# Altium schematic sheet Solder_DisScan_1B.SchDoc
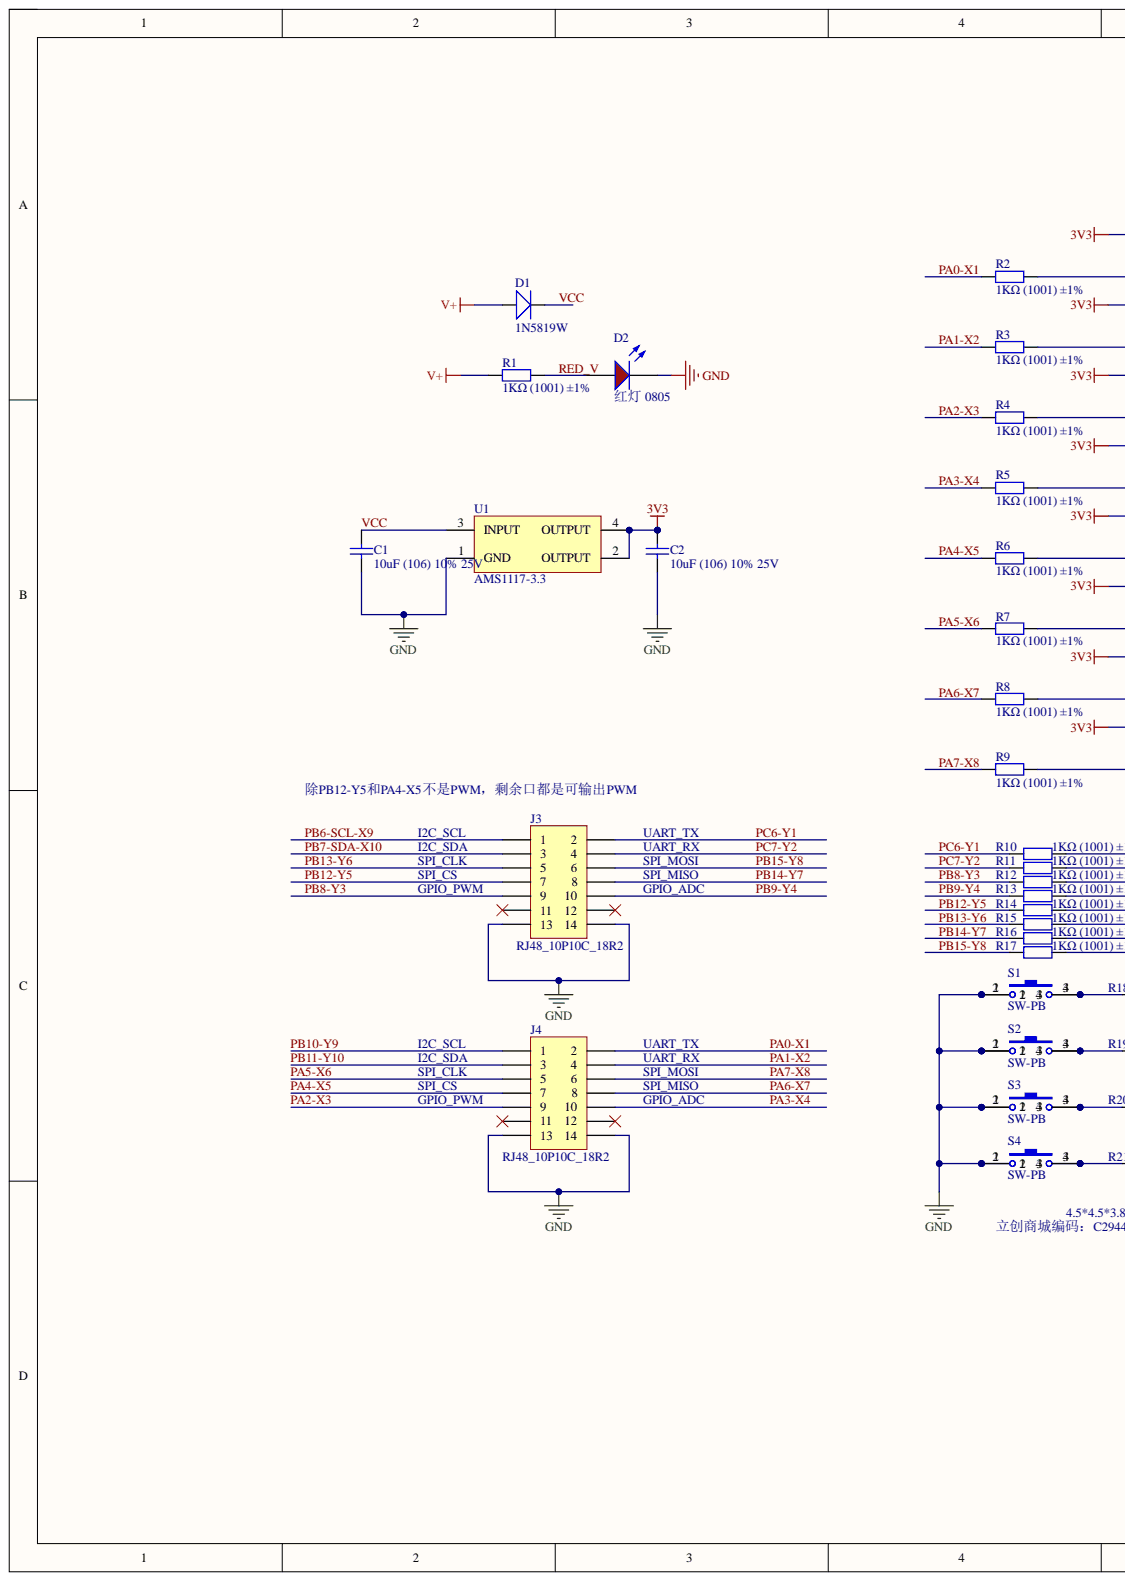
[diagram p0: Solder_DisScan_1B.SchDoc, left]
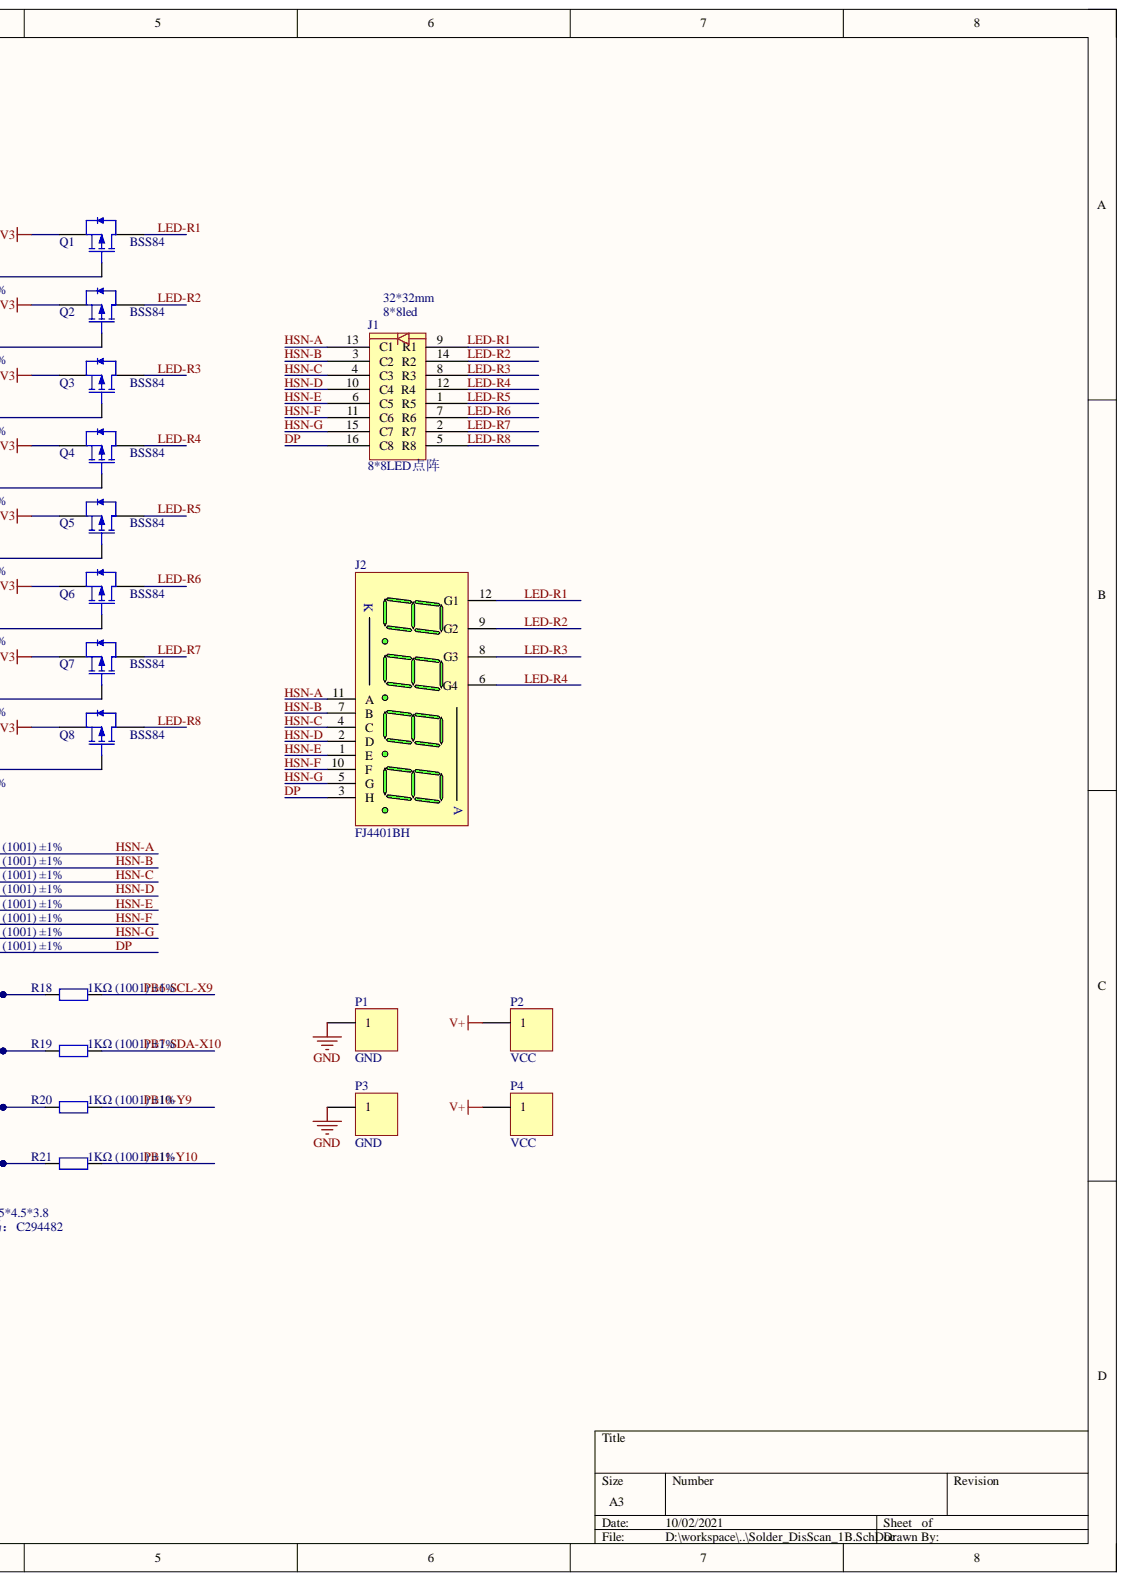
[diagram p1: Solder_DisScan_1B.SchDoc, right]

## Parts
C1  10uF (106) 10% 25V  [0805_C]
C2  10uF (106) 10% 25V  [0805_C]
D1  1N5819W  [SOD-123]
D2  红灯 0805  Package: 0805  [0805_LED_S1]
J1  8*8LED点阵  [8*8点阵LED]
J2  FJ4401BH  [FJ4401BH]
J3  RJ48_10P10C_18R2  [RJ48]
J4  RJ48_10P10C_18R2  [RJ48]
P1  GND  [HOLE]
P2  VCC  [HOLE]
P3  GND  [HOLE]
P4  VCC  [HOLE]
Q1  BSS84  [SOT-23(SOT-23-3)]
Q2  BSS84  [SOT-23(SOT-23-3)]
Q3  BSS84  [SOT-23(SOT-23-3)]
Q4  BSS84  [SOT-23(SOT-23-3)]
Q5  BSS84  [SOT-23(SOT-23-3)]
Q6  BSS84  [SOT-23(SOT-23-3)]
Q7  BSS84  [SOT-23(SOT-23-3)]
Q8  BSS84  [SOT-23(SOT-23-3)]
R1  1KΩ (1001) ±1%  [0805_R]
R2  1KΩ (1001) ±1%  [0805_R]
R3  1KΩ (1001) ±1%  [0805_R]
R4  1KΩ (1001) ±1%  [0805_R]
R5  1KΩ (1001) ±1%  [0805_R]
R6  1KΩ (1001) ±1%  [0805_R]
R7  1KΩ (1001) ±1%  [0805_R]
R8  1KΩ (1001) ±1%  [0805_R]
R9  1KΩ (1001) ±1%  [0805_R]
R10  1KΩ (1001) ±1%  [0805_R]
R11  1KΩ (1001) ±1%  [0805_R]
R12  1KΩ (1001) ±1%  [0805_R]
R13  1KΩ (1001) ±1%  [0805_R]
R14  1KΩ (1001) ±1%  [0805_R]
R15  1KΩ (1001) ±1%  [0805_R]
R16  1KΩ (1001) ±1%  [0805_R]
R17  1KΩ (1001) ±1%  [0805_R]
R18  1KΩ (1001) ±1%  [0805_R]
R19  1KΩ (1001) ±1%  [0805_R]
R20  1KΩ (1001) ±1%  [0805_R]
R21  1KΩ (1001) ±1%  [0805_R]
S1  SW-PB  [SWITCH4_4.5*4.5*4.8]
S2  SW-PB  [SWITCH4_4.5*4.5*4.8]
S3  SW-PB  [SWITCH4_4.5*4.5*4.8]
S4  SW-PB  [SWITCH4_4.5*4.5*4.8]
U1  AMS1117-3.3  [SOT223_N]

## Nets
3V3: C2.1 Q1.2 Q2.2 Q3.2 Q4.2 Q5.2 Q6.2 Q7.2 Q8.2 U1.2(OUTPUT) U1.4(OUTPUT)
GND: C1.2 C2.2 D2.K J3.13 J3.14 J4.13 J4.14 P1.1 P3.1 S1.1 S1.2 S2.1 S2.2 S3.1 S3.2 S4.1 S4.2 U1.1(GND)
V+: D1.1 P2.1 P4.1 R1.1
DP: J1.16(C8) J2.3(H) R17.2
HSN-A: J1.13(C1) J2.11(A) R10.2
HSN-B: J1.3(C2) J2.7(B) R11.2
HSN-C: J1.4(C3) J2.4(C) R12.2
HSN-D: J1.10(C4) J2.2(D) R13.2
HSN-E: J1.6(C5) J2.1(E) R14.2
HSN-F: J1.11(C6) J2.10(F) R15.2
HSN-G: J1.15(C7) J2.5(G) R16.2
LED-R1: J1.9(R1) J2.12(G1) Q1.3
LED-R2: J1.14(R2) J2.9(G2) Q2.3
LED-R3: J1.8(R3) J2.8(G3) Q3.3
LED-R4: J1.12(R4) J2.6(G4) Q4.3
LED-R5: J1.1(R5) Q5.3
LED-R6: J1.7(R6) Q6.3
LED-R7: J1.2(R7) Q7.3
LED-R8: J1.5(R8) Q8.3
PA0-X1: J4.2 R2.1
PA1-X2: J4.4 R3.1
PA2-X3: J4.9 R4.1
PA3-X4: J4.10 R5.1
PA4-X5: J4.7 R6.1
PA5-X6: J4.5 R7.1
PA6-X7: J4.8 R8.1
PA7-X8: J4.6 R9.1
PB6-SCL-X9: J3.1 R18.2
PB7-SDA-X10: J3.3 R19.2
PB8-Y3: J3.9 R12.1
PB9-Y4: J3.10 R13.1
PB10-Y9: J4.1 R20.2
PB11-Y10: J4.3 R21.2
PB12-Y5: J3.7 R14.1
PB13-Y6: J3.5 R15.1
PB14-Y7: J3.8 R16.1
PB15-Y8: J3.6 R17.1
PC6-Y1: J3.2 R10.1
PC7-Y2: J3.4 R11.1
RED_V: D2.A R1.2
VCC: C1.1 D1.2 U1.3(INPUT)
NetQ1_1: Q1.1 R2.2
NetQ2_1: Q2.1 R3.2
NetQ3_1: Q3.1 R4.2
NetQ4_1: Q4.1 R5.2
NetQ5_1: Q5.1 R6.2
NetQ6_1: Q6.1 R7.2
NetQ7_1: Q7.1 R8.2
NetQ8_1: Q8.1 R9.2
NetR18_1: R18.1 S1.3 S1.4
NetR19_1: R19.1 S2.3 S2.4
NetR20_1: R20.1 S3.3 S3.4
NetR21_1: R21.1 S4.3 S4.4

Not connected: J3 11 12; J4 11 12

## Library data of the parts
C1 C2 = 0805 10uF (106) 10% 25V: 贴片电容; Component Kind: Standard; ComponentLink1Description: 供应商链接; ComponentLink1URL: http://www.szlcsc.com/product/details_16532.html; Library Name: Cap.SchLib; Package: 0805; Supplier: LC; SuppliersPartNumber: C15850
D1 = 1N5819W: Resistor; Component Kind: Standard; LatestRevisionDate: 29-May-2009; LatestRevisionNote: IPC-7351 Footprint Added.; PackageDescription: Chip Resistor; Published: 8-Jun-2000; Signal Integrity: Res; Simulation: RESISTOR; Value: 1N5819W; Library Name: 温湿度传感器_Project.SCHLIB; Publisher: Altium Limited
D2 = 0805 红灯: 发光二极管; Component Kind: Standard; ComponentLink1Description: 供应商链接; ComponentLink1URL: http://www.szlcsc.com/product/details_2668.html; Library Name: LED.SchLib; Supplier: LC; SuppliersPartNumber: C2295
J1 = 8*8LED点阵: 8*8LED点阵，3mm, 16pin, 32*32; 厂家型号: SLD1388DRA1GD; 品　　牌: SUNLIGHT; Component Kind: Standard; ComponentLink1Description: 供应商链接; ComponentLink1URL: https://item.szlcsc.com/226241.html; Package: 外形32*32,引脚17.78*24, 16pin,共阳; Supplier: LC; SuppliersPartNumber: C225991; unit price: ￥6.72 / 个; Library Name: Solder_DisScan_1A.SCHLIB
J2 = DPY-4CA: 共阳4位数码管; 品　　牌: 志浩; Component Kind: Standard; ComponentLink1Description: 供应商链接; ComponentLink1URL: https://item.szlcsc.com/11256.html; Package: 外形16*40.4,引脚12.7*12.7,12pin; PackageDescription: 四位0.4英寸红色共阳数码管; Supplier: LC; SuppliersPartNumber: C10712; unit price: ￥3.43 / 个; 厂家型号: FJ4401BH; Library Name: Fszhang.IntLib
J3 J4 = Header 7X2: Header, 7-Pin, Dual row; Component Kind: Standard; LatestRevisionDate: 17-Jul-2002; LatestRevisionNote: Re-released for DXP Platform.; Library Name: Miscellaneous Connectors.IntLib; Signal Integrity: Connector; Publisher: Altium Limited
P1-P4 = Header 2: Header, 2-Pin; Component Kind: Standard; LatestRevisionDate: 17-Jul-2002; LatestRevisionNote: Re-released for DXP Platform.; Library Name: Miscellaneous Connectors.IntLib; Signal Integrity: Connector; Publisher: Altium Limited
Q1-Q8 = BSS84LT1G: MOS(场效应管); Component Kind: Standard; ComponentLink1Description: 供应商链接; ComponentLink1URL: https://item.szlcsc.com/113470.html; Library Name: MOS.SchLib; Package: SOT-23(SOT-23-3); Supplier: LC; SuppliersPartNumber: C112238
R1-R21 = 0805 1KΩ (1001) 1%: 贴片电阻; Component Kind: Standard; ComponentLink1Description: 供应商链接; ComponentLink1URL: http://www.szlcsc.com/product/details_18201.html; Package: 0805; Supplier: LC; SuppliersPartNumber: C17513
  R1: Library Name: RES.SchLib
  R2-R21: Library Name: Miscellaneous Devices LC.IntLib
S1-S4 = SW-PB: Switch; 厂家型号: TSA451G38-250; 品　　牌: BRIGHT; 封装规格: Through Hole; Component Kind: Standard; ComponentLink1Description: 供应商链接; ComponentLink1URL: https://item.szlcsc.com/279029.html; LatestRevisionNote: Re-released for DXP Platform.; Package: 外形4.5*4.5*3.8,引脚5*3; PackageDescription: Switch; 4 Leads; PackageReference: SPST-4; Supplier: LC; SuppliersPartNumber: C294482; unit price: ￥0.131263 / 个; Library Name: KeypadArray_1A.SCHLIB
U1 = TLV1117LV33DCY: Component Kind: Standard; Library Name: 1U.SchLib
